# Altium schematic sheet [5]_Power_Supply.SchDoc([5]_Power_Supply)
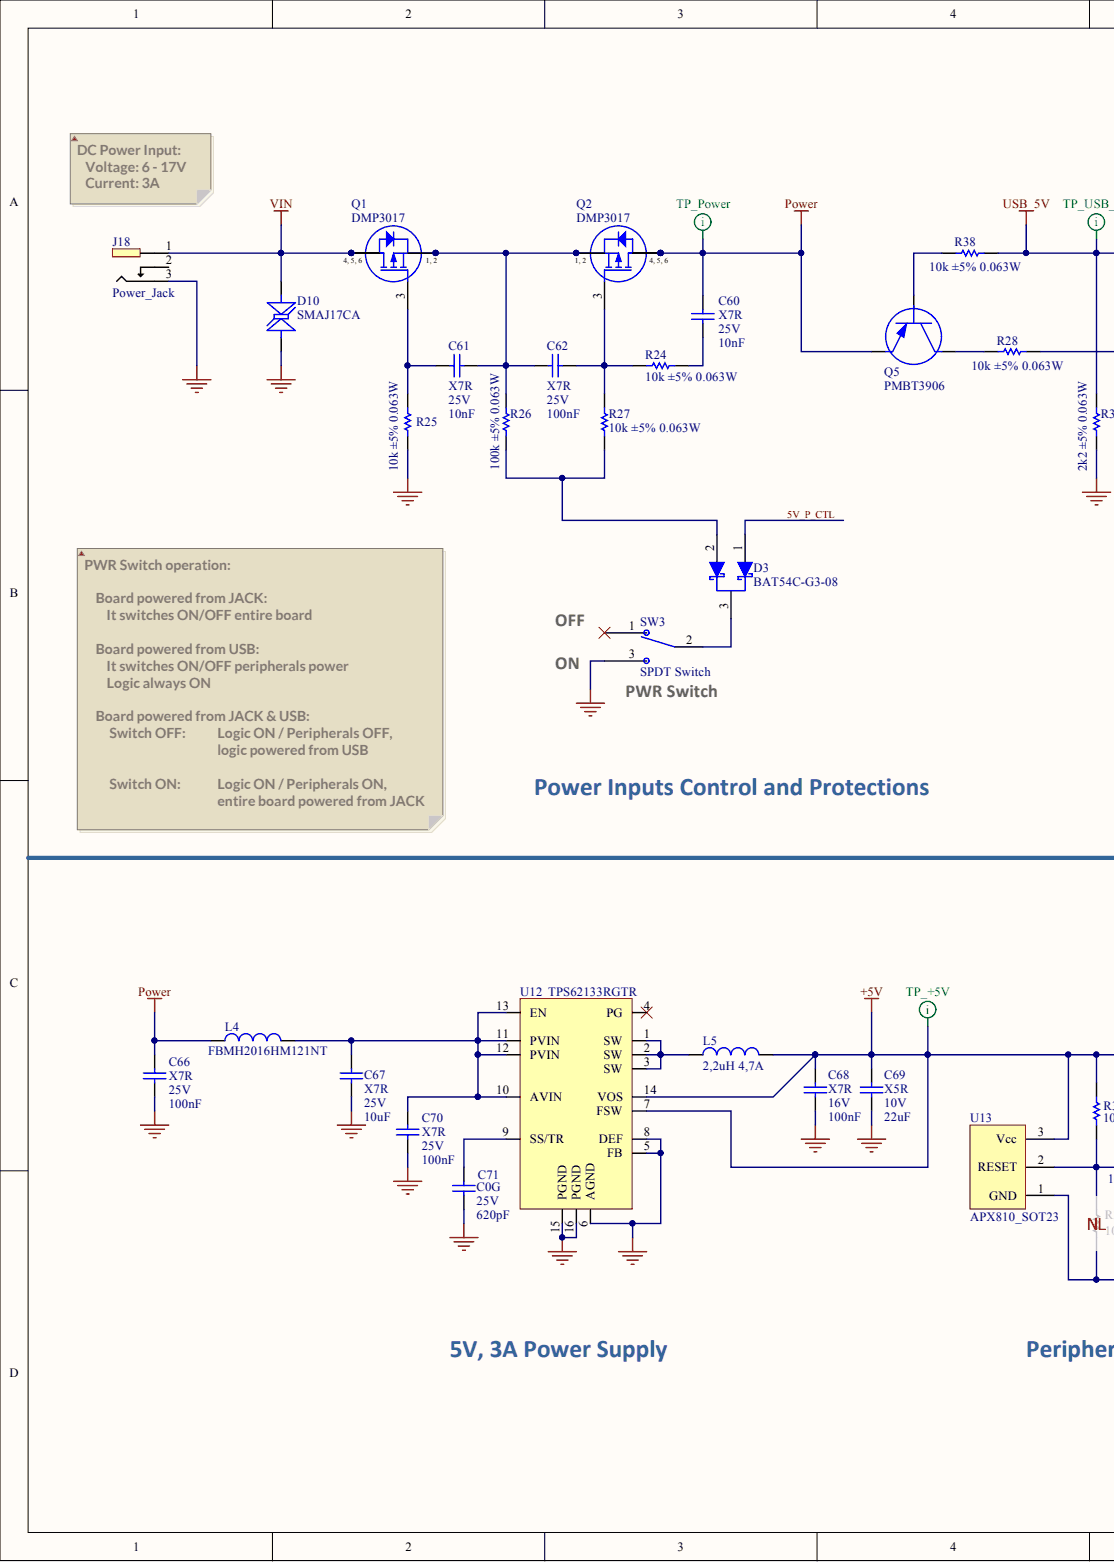
[diagram p0: [5]_Power_Supply.SchDoc, left]
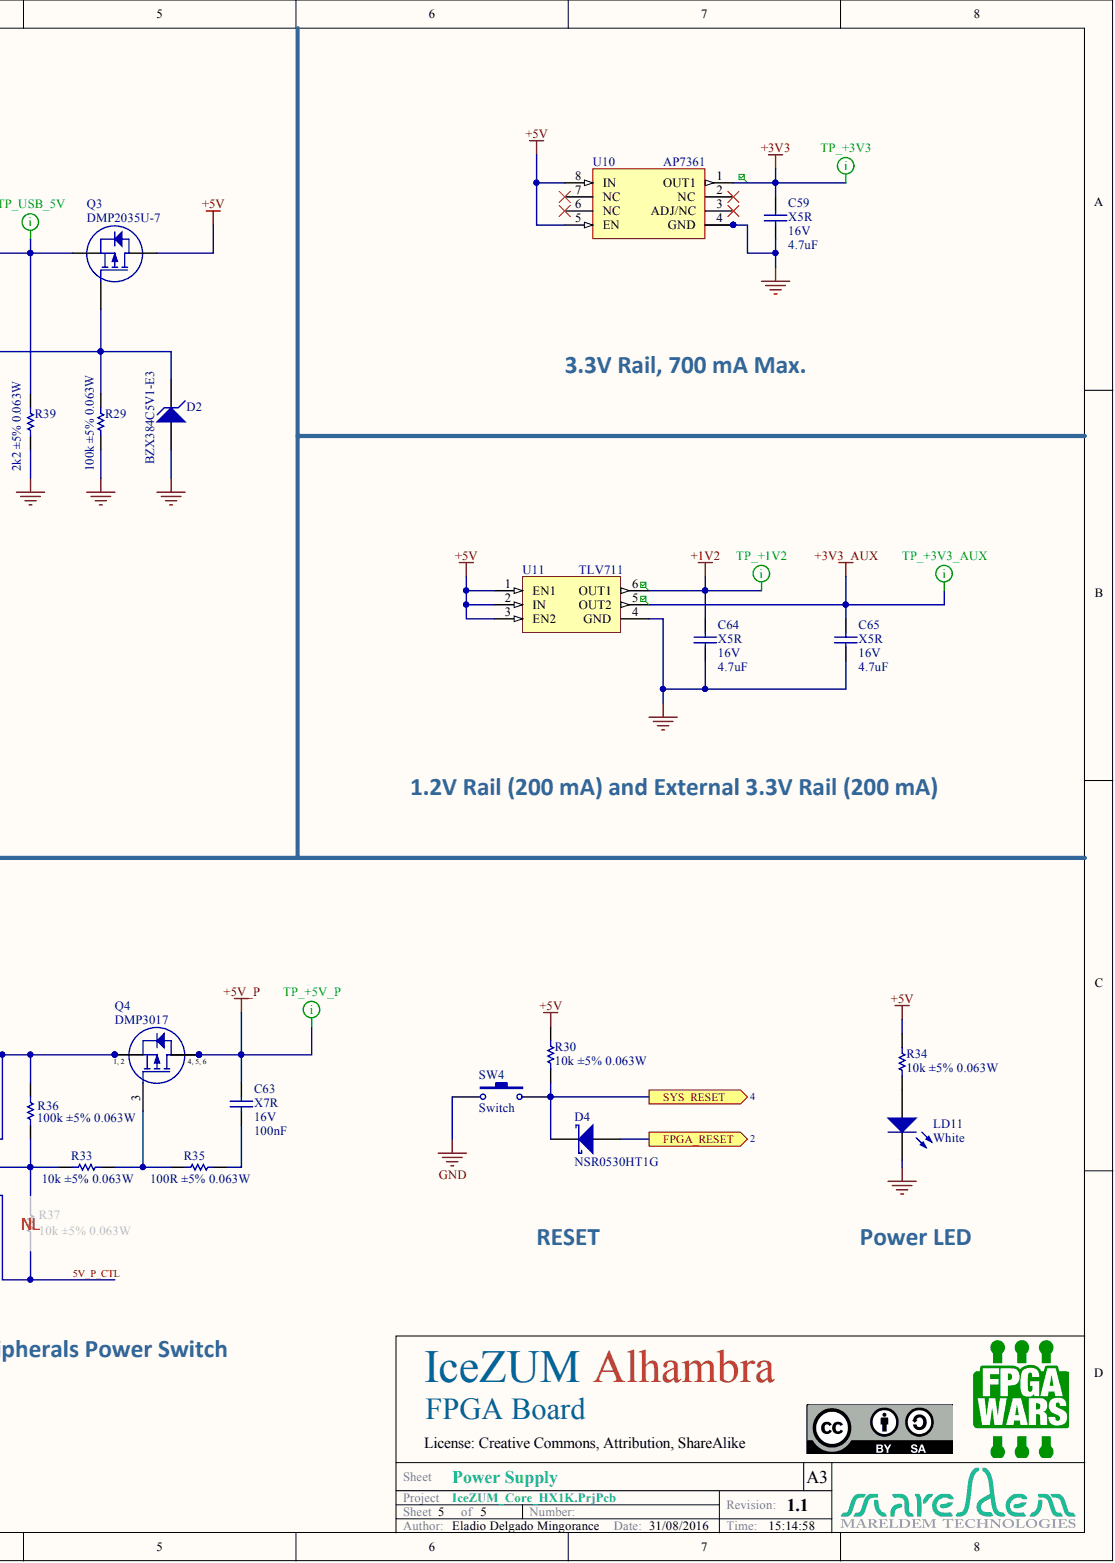
[diagram p1: [5]_Power_Supply.SchDoc, right]

## Parts
C59  Capacitance: 4.7uF  Dielectric: X5R  Voltage: 16V  [C0603]  (hidden comment: Capacitor)
C60  Capacitance: 10nF  Dielectric: X7R  Voltage: 25V  [C0603]  (hidden comment: Capacitor)
C61  Dielectric: X7R  Voltage: 25V  [C0603]  (hidden comment: Capacitor)
C62  Dielectric: X7R  Voltage: 25V  [C0603]  (hidden comment: Capacitor)
C63  Capacitance: 100nF  Dielectric: X7R  Voltage: 16V  [C0402]  (hidden comment: Capacitor)
C64  Capacitance: 4.7uF  Dielectric: X5R  Voltage: 16V  [C0603]  (hidden comment: Capacitor)
C65  Capacitance: 4.7uF  Dielectric: X5R  Voltage: 16V  [C0603]  (hidden comment: Capacitor)
C66  Capacitance: 100nF  Dielectric: X7R  Voltage: 25V  [C0603]  (hidden comment: Capacitor)
C67  Dielectric: X7R  Voltage: 25V  [C1206]  (hidden comment: Capacitor)
C68  Capacitance: 100nF  Dielectric: X7R  Voltage: 16V  [C0402]  (hidden comment: Capacitor)
C69  Capacitance: 22uF  Dielectric: X5R  Voltage: 10V  [C1206]  (hidden comment: Capacitor)
C70  Capacitance: 100nF  Dielectric: X7R  Voltage: 25V  [C0603]  (hidden comment: Capacitor)
C71  Capacitance: 620pF  Dielectric: C0G  Voltage: 25V  [C0603]  (hidden comment: Capacitor)
D2  BZX384C5V1-E3  [SOD323]
D3  BAT54C-G3-08  [SOT23-3]
D4  NSR0530HT1G  [SOD323]
D10  SMAJ17CA  [DO-214AC-SMA_Bidir]
J18  Power_Jack  [Jack 2.1]
L4  FBMH2016HM121NT  [L0806]
L5  2,2uH 4,7A  Current: 4,7A  L: 2,2uH  [L4040]
LD11  White  [LED0603]
Q1  DMP3017  [U-DFN2523-6]
Q2  DMP3017  [U-DFN2523-6]
Q3  DMP2035U-7  [SOT23-3]
Q4  DMP3017  [U-DFN2523-6]
Q5  PMBT3906  [SOT23-3]
R24  value 10k ±5% 0.063W  Power: 0.063W  Resistance: 10k  Tolerance: ±5%  [R0402]  (hidden comment: Resistor)
R25  value 10k ±5% 0.063W  Power: 0.063W  Resistance: 10k  Tolerance: ±5%  [R0402]  (hidden comment: Resistor)
R26  value 100k ±5% 0.063W  Power: 0.063W  Resistance: 100k  Tolerance: ±5%  [R0402]  (hidden comment: Resistor)
R27  value 10k ±5% 0.063W  Resistance: 10k  Tolerance: ±5%  [R0402]  (hidden comment: Resistor)
R28  value 10k ±5% 0.063W  Power: 0.063W  Resistance: 10k  Tolerance: ±5%  [R0402]  (hidden comment: Resistor)
R29  value 100k ±5% 0.063W  Power: 0.063W  Resistance: 100k  Tolerance: ±5%  [R0402]  (hidden comment: Resistor)
R30  value 10k ±5% 0.063W  Resistance: 10k  Tolerance: ±5%  [R0402]  (hidden comment: Resistor)
R33  value 10k ±5% 0.063W  Power: 0.063W  Resistance: 10k  Tolerance: ±5%  [R0402]  (hidden comment: Resistor)
R34  value 10k ±5% 0.063W  Resistance: 10k  Tolerance: ±5%  [R0402]  (hidden comment: Resistor)
R35  value 100R ±5% 0.063W  Power: 0.063W  Resistance: 100R  Tolerance: ±5%  [R0402]  (hidden comment: Resistor)
R36  value 100k ±5% 0.063W  Resistance: 100k  Tolerance: ±5%  [R0402]  (hidden comment: Resistor)
R37  value 10k ±5% 0.063W  Resistance: 10k  Tolerance: ±5%  [R0402]  (hidden comment: Resistor)
R38  value 10k ±5% 0.063W  Power: 0.063W  Resistance: 10k  Tolerance: ±5%  [R0402]  (hidden comment: Resistor)
R39  value 2k2 ±5% 0.063W  Power: 0.063W  Resistance: 2k2  Tolerance: ±5%  [R0402]  (hidden comment: Resistor)
SW3  SPDT Switch  [Slide SW SPDT Right Angle]
SW4  Switch  [SW_Vert_THT_7.5x6x6.5]
U10  AP7361  [U-DFN3030-8]
U11  TLV711  [WSON-6-1,5x1,5mm]
U12  TPS62133RGTR  [QFN16]
U13  APX810_SOT23  [SOT23-3]

## Ports
FPGA_RESET, SYS_RESET

## Nets
+1V2: C64.2 U11.6(OUT1)
+3V3: C59.2 U10.1(OUT1)
+3V3_AUX: C65.2 U11.5(OUT2)
+5V: C68.2 C69.2 L5.2 Q3.3 Q4.1 Q4.2 R30.2 R34.2 R36.1 U10.5(EN) U10.8(IN) U11.1(EN1) U11.2(IN) U11.3(EN2) U12.7(FSW) U12.14(VOS) U13.3
+5V_P: C63.2 Q4.4 Q4.5 Q4.6
GND: C59.1 C64.1 C65.1 C66.1 C67.1 C68.1 C69.1 C70.1 C71.1 D2.A D10.A J18.3 LD11.K R25.2 R29.1 R39.1 SW3.3 SW4.2 U10.0(GND) U10.4 U11.4(GND) U12.5(FB) U12.6(AGND) U12.8(DEF) U12.15(PGND) U12.16(PGND)
POWER: C60.2 C66.2 L4.1 Q2.4 Q2.5 Q2.6 Q5.2
USB_5V: Q3.2 R38.2 R39.2
VIN: D10.K J18.1 Q1.4 Q1.5 Q1.6
5V_P_CTL: D3.1 R37.2 U13.1
FPGA_RESET: D4.A ; port FPGA_RESET
SYS_RESET: D4.k R30.1 SW4.1 ; port SYS_RESET
NetC60_1: C60.1 R24.2
NetC61_1: C61.1 C62.2 Q1.1 Q1.2 Q2.1 Q2.2 R26.1
NetC61_2: C61.2 Q1.3 R25.1
NetC62_1: C62.1 Q2.3 R24.1 R27.1
NetC63_1: C63.1 R35.2
NetC67_2: C67.2 C70.2 L4.2 U12.10(AVIN) U12.11(PVIN) U12.12(PVIN) U12.13(EN)
NetC71_2: C71.2 U12.9(SS/TR)
NetD2_k: D2.k Q3.1 R28.2 R29.2
NetD3_2: D3.2 R26.2 R27.2
NetD3_3: D3.3 SW3.2
NetL5_1: L5.1 U12.1(SW) U12.2(SW) U12.3(SW)
NetLD11_A: LD11.A R34.1
NetQ4_3: Q4.3 R33.2 R35.1
NetQ5_1: Q5.1 R38.1
NetQ5_3: Q5.3 R28.1
NetR33_1: R33.1 R36.2 R37.1 U13.2

Not connected: J18 2; SW3 1; U10 2(NC) 3(ADJ/NC) 6(NC) 7(NC); U12 4(PG)

## Library data of the parts
C59 C64 C65 = C0603_4.7uF_16V_±10%: Capacitor SMD; Manufacturer: TDK; Database Table Name: C0603_16V$; OrderingCode: C1608X5R1C475K080AC; Temp_ Max: 85; Temp_ Min: -55; Tolerance: ±10%; Value: 4.7uF ±10% 16V; Component Kind: Standard; Library Name: Pasives_Capacitor_SMD.DbLib; Part Number: C0603_4.7uF_16V_±10%; Price (€): 0,068
C60 = C0603_10nF_25V_±10%: Capacitor SMD; Manufacturer: Kemet; Database Table Name: C0603_25V$; OrderingCode: C0603C103K3RAC; Temp_ Max: 125; Temp_ Min: -55; Tolerance: ±10%; Value: 10nF ±10% 25V; Component Kind: Standard; Library Name: Pasives_Capacitor_SMD.DbLib; Part Number: C0603_10nF_25V_±10%; Price (€): 0,035
C61 = C0603_10nF_25V_±10%: Capacitor SMD; Manufacturer: Kemet; Capacitance: 10nF; Database Table Name: C0603_25V$; OrderingCode: C0603C103K3RAC; Temp_ Max: 125; Temp_ Min: -55; Tolerance: ±10%; Value: 10nF ±10% 25V; Component Kind: Standard; Library Name: Pasives_Capacitor_SMD.DbLib; Part Number: C0603_10nF_25V_±10%; Price (€): 0,035
C62 = C0603_100nF_25V_±10%: Capacitor SMD; Manufacturer: Kemet; Capacitance: 100nF; Database Table Name: C0603_25V$; OrderingCode: C0603C104K3RAC; Temp_ Max: 125; Temp_ Min: -55; Tolerance: ±10%; Value: 100nF ±10% 25V; Component Kind: Standard; Library Name: Pasives_Capacitor_SMD.DbLib; Part Number: C0603_100nF_25V_±10%; Price (€): 0,035
C63 C68 = C0402_100nF_16V_±10%: Capacitor SMD; Manufacturer: Kemet; Database Table Name: C0402_16V$; OrderingCode: C0402C104K4RAC; Temp_ Max: 125; Temp_ Min: -55; Tolerance: ±10%; Value: 100nF ±10% 16V; Component Kind: Standard; Library Name: Pasives_Capacitor_SMD.DbLib; Part Number: C0402_100nF_16V_±10%; Price (€): 0,05
C66 C70 = C0603_100nF_25V_±10%: Manufacturer: Kemet; Database Table Name: C0603_25V$; OrderingCode: C0603C104K3RAC; Temp_ Max: 125; Temp_ Min: -55; Tolerance: ±10%; Value: 100nF ±10% 25V; Component Kind: Standard; Description: Capacitor; Library Name: Pasives_Capacitor_SMD.DbLib; Part Number: C0603_100nF_25V_±10%; Price (€): 0,035
C67 = C1206_10uF_25V_±10%: Capacitor SMD; Manufacturer: Taiyo Yuden; Capacitance: 10uF; Database Table Name: C1206_25V$; OrderingCode: TMK316B7106KL-TD; Temp_ Max: 125; Temp_ Min: -55; Tolerance: ±10%; Value: 10uF ±10% 25V; Component Kind: Standard; Library Name: Pasives_Capacitor_SMD.DbLib; Part Number: C1206_10uF_25V_±10%; Price (€): 0,066
C69 = C1206_22uF_10V_±20%: Manufacturer: Taiyo Yuden; Database Table Name: C1206_10V$; OrderingCode: LMK316ABJ226ML-T; Temp_ Max: 85; Temp_ Min: -55; Tolerance: ±20%; Value: 22uF ±20% 10V; Component Kind: Standard; Description: Capacitor; Library Name: Pasives_Capacitor_SMD.DbLib; Part Number: C1206_22uF_10V_±20%; Price (€): 0,094
C71 = C0603_620pF_25V_±5%: Manufacturer: Murata; Database Table Name: C0603_25V$; OrderingCode: GRM1885C1E621JA01D; Temp_ Max: 125; Temp_ Min: -55; Tolerance: ±5%; Value: 620pF ±5% 25V; Component Kind: Standard; Description: Capacitor; Library Name: Pasives_Capacitor_SMD.DbLib; Part Number: C0603_620pF_25V_±5%; Price (€): 0,023
D2 = BZX384C5V1: Zener Diode; Manufacturer: Vishay; Database Table Name: Zener$; Izener: 5mA; OrderingCode 2: BZX384B5V1-E3; Power: 200mW; Temp_ Max: 150; Temp_ Min: -55; Tolerance: 0,05; Value: 5V1; Component Kind: Standard; Library Name: Diodes.DbLib; Manufacturer 2: Vishay; OrderingCode: BZX384C5V1-E3; Part Number: BZX384C5V1; Price (€): 0,024; Vzener: 5V1
D3 = BAT54C: Dual Schottky Diode Common Cathode; Manufacturer: Vishay; Database Table Name: Schottky$; Ifor: 200mA; Ifsm: 600mA; Temp_ Max: 125; Temp_ Min: -55; Value: 200mA 30V; Vf: 0,8V; Vrrm: 30V; Component Kind: Standard; Library Name: Diodes.DbLib; Manufacturer 2: Fairchild; OrderingCode: BAT54C-G3-08; OrderingCode 2: BAT54C; Part Number: BAT54C; Price (€): 0,042
D4 = NSR0530HT1G: Schottky Diode; Manufacturer: On Semiconductor; Database Table Name: Schottky$; Ifor: 500mA; Temp_ Max: 150; Temp_ Min: -55; Value: 500mA 30V; Vf: 0,37V; Vrrm: 30V; Component Kind: Standard; Ifsm: 500mA; Library Name: Diodes.DbLib; OrderingCode: NSR0530HT1G; Part Number: NSR0530HT1G; Price (€): 0,031
D10 = TVS 17V Bi SMA: Protection, Bidirectional Transient Suppression Diodes; Manufacturer: Bourns; Breakdown Voltage: 18,9V; Database Table Name: TVS$; Overvoltage Current: 14,5A; Temp_ Max: 150; Temp_ Min: -55; Voltage: 17V; Component Kind: Standard; Library Name: Protection.DbLib; Manufacturer 2: Littelfuse; OrderingCode: SMAJ17CA; OrderingCode 2: SMAJ17CA; Part Number: TVS 17V Bi SMA; Price (€): 0,113
J18 = DC Power Jack: Connector, Power, DC Power Jack; Manufacturer: Kycon; Database Table Name: Jack$; Idc: 5A; OrderingCode: KLDHCX0202A; OrderingCode 2: RAPC722X; Component Kind: Standard; Library Name: Connectors_Power.DbLib; Manufacturer 2: Switchcraft; Part Number: DC Power Jack; Price (€): 0,2673; Supplier: Avnet
L4 = L0806_120R_0,015R_4,5A: Ferrite Bead; Manufacturer: Taiyo Yuden; Current: 4,5A; Database Table Name: Ferritas$; Resistance_100MHz: 120R; Resistance_DC: 0,015R; Temp_ Max: 125; Temp_ Min: -40; Value: 120R 0,015R 4,5A; Component Kind: Standard; Library Name: Pasives_Inductor_SMD.DbLib; OrderingCode: FBMH2016HM121NT; Part Number: L0806_120R_0,015R_4,5A; Price (€): 0,05
L5 = 2,2uH_4,7A: Inductor SMD; Manufacturer: Würth Elektronik; Current Sat#: 6,2A; Database Table Name: Power Inductor$; OrderingCode: 74438356022; OrderingCode 2: XAL4020-222MEB; Rdc: 0,029R; Temp_ Max: 125; Temp_ Min: -40; Component Kind: Standard; Library Name: Pasives_Inductor_SMD.DbLib; Manufacturer 2: Coilcraft; Part Number: 2,2uH_4,7A; Price (€): 1,22; Supplier: Würth; Value: 2,2uH 4,7A
LD11 = LW Q38G-Q2R3: LED; Manufacturer: OSRAM Opto; Database Table Name: LED_SMD$; OrderingCode: LW Q38G-Q2R2-3K5L-1; Temp_ Max: 85; Temp_ Min: -40; Component Kind: Standard; Library Name: Optoelectronics.SVNDbLib; Part Number: LW Q38G-Q2R3; Price (€): 0,1; Value: White
Q1 Q2 Q4 = DMP3017: P-Channel MOSFET, 6 pin; Manufacturer: Diodes Incorporated; Database Table Name: MOSFET$; Id: 10,4A; OrderingCode: DMP3017SFK-7; Temp_ Max: 150; Temp_ Min: -55; Vds: 30V; Vgss: 25V; Component Kind: Standard; Library Name: Transistors.DbLib; Part Number: DMP3017; Price (€): 0,256; Supplier: Silica
Q3 = DMP2035U: PFET; Manufacturer: Diodes Incorporated; Database Table Name: MOSFET$; Id: 3,6A; Temp_ Max: 150; Temp_ Min: -55; Vds: 20V; Vgss: 8V; Component Kind: Standard; Library Name: Semiconductors_Transistors.SVNDbLib; OrderingCode: DMP2035U-7; Part Number: DMP2035U; Price (€): 0,08; Supplier: Silica
Q5 = PMBT3906: PNP; Manufacturer: NXP; Database Table Name: BJT$; Ic: 200mA; OrderingCode: PMBT3906,215; Temp_ Max: 150; Temp_ Min: -65; Vcbo: -40V; Vebo: -6V; Component Kind: Standard; Library Name: Semiconductors_Transistors.SVNDbLib; Part Number: PMBT3906; Price (€): 0,019; Vceo: -40V
R24 R25 R28 R33 R38 = R0402_10k_0.063W_±5%: Resistor, SMD; Manufacturer: Vishay; Database Table Name: R0402_5%$; OrderingCode: CRCW040210K0JN; Temp_ Max: 155; Temp_ Min: -55; Component Kind: Standard; Library Name: Pasives_Resistor_SMD.DbLib; PackageDescription: SMD; Part Number: R0402_10k_0.063W_±5%; Price (€): 0,003
R26 R29 = R0402_100k_0.063W_±5%: Resistor, SMD; Manufacturer: Vishay; Database Table Name: R0402_5%$; OrderingCode: CRCW0402100KJN; Temp_ Max: 155; Temp_ Min: -55; Component Kind: Standard; Library Name: Pasives_Resistor_SMD.DbLib; PackageDescription: SMD; Part Number: R0402_100k_0.063W_±5%; Price (€): 0,003
R27 R30 R34 R37 = R0402_10k_0.063W_±5%: Resistor, SMD; Manufacturer: Vishay; Database Table Name: R0402_5%$; OrderingCode: CRCW040210K0JN; Power: 0.063W; Temp_ Max: 155; Temp_ Min: -55; Component Kind: Standard; Library Name: Pasives_Resistor_SMD.DbLib; PackageDescription: SMD; Part Number: R0402_10k_0.063W_±5%; Price (€): 0,003
R35 = R0402_100R_0.063W_±5%: Resistor, SMD; Manufacturer: Vishay; Database Table Name: R0402_5%$; OrderingCode: CRCW0402100RJN; Temp_ Max: 155; Temp_ Min: -55; Component Kind: Standard; Library Name: Pasives_Resistor_SMD.DbLib; PackageDescription: SMD; Part Number: R0402_100R_0.063W_±5%; Price (€): 0,003
R36 = R0402_100k_0.063W_±5%: Resistor, SMD; Manufacturer: Vishay; Database Table Name: R0402_5%$; OrderingCode: CRCW0402100KJN; Power: 0.063W; Temp_ Max: 155; Temp_ Min: -55; Value: 100k ±5% 0.063W; Component Kind: Standard; Library Name: Pasives_Resistor_SMD.DbLib; PackageDescription: SMD; Part Number: R0402_100k_0.063W_±5%; Price (€): 0,003
R39 = R0402_2k2_0.063W_±5%: Resistor, SMD; Manufacturer: Vishay; Database Table Name: R0402_5%$; OrderingCode: CRCW04022K20JN; Temp_ Max: 155; Temp_ Min: -55; Component Kind: Standard; Library Name: Pasives_Resistor_SMD.DbLib; PackageDescription: SMD; Part Number: R0402_2k2_0.063W_±5%; Price (€): 0,003
SW3 = Switch SPDT: Manufacturer: Würth Elektronik; Database Table Name: Switch$; OrderingCode: 450 302 014 072; OrderingCode 2: MMP1010D-RA; Temp_ Max: 85; Temp_ Min: -40; Component Kind: Standard; Description: SPDT Switch; Library Name: Electromechanical.SVNDbLib; Manufacturer 2: Knitter-Switch; Part Number: Switch SPDT; Price (€): 0,4144; Supplier: Würth
SW4 = SW Vertical THT: Micro miniature pushbutton surface Switch; Manufacturer: C&K Components; Database Table Name: Switch$; OrderingCode: PTS645VL39-2 LFS; Temp_ Max: 60; Temp_ Min: -20; Component Kind: Standard; Library Name: Electromechanical.SVNDbLib; Part Number: SW Vertical THT; Price (€): 0,104; Supplier: Abacus
U10 = AP7361: LDO Regulator, 8 pin, adjustable and fixed mode with enable; Manufacturer: Diodes Inc.; Current OUT: 1A; Database Table Name: LDO$; OrderingCode: AP7361-33FGE-7; Temp_ Max: 85; Temp_ Min: -40; Voltage IN: 2,2÷6 VDC; Voltage OUT: 3.3VDC; Component Kind: Standard; Library Name: Power_Regulator.DbLib; Part Number: AP7361; Price (€): 0,187; Supplier: Silica
U11 = TLV711: LDO Regulator, Dual, 6 pin; Manufacturer: Texas Instruments; Current OUT: 200 & 200 mA; Database Table Name: LDO$; OrderingCode: TLV7111233DSER; Temp_ Max: 125; Temp_ Min: -40; Voltage IN: 2÷5,5 VDC; Voltage OUT: 1,2 & 3,3 V; Component Kind: Standard; Library Name: Power_Regulator.DbLib; Part Number: TLV711; Price (€): 0,224; Supplier: Silica
U12 = TPS62133: 3A High Efficient Synchronous Step Down Converter with DCS™ Control; Manufacturer: Texas Instruments; Database Table Name: DC_DC_Switching_Reg$; Temp_ Max: 85; Temp_ Min: -40; Voltage IN: 3÷17 VDC; Voltage OUT: 0,9÷6 VDC; Component Kind: Standard; Current OUT: 3A; Library Name: Power_Regulator.DbLib; OrderingCode: TPS62133RGTR; Part Number: TPS62133; Price (€): 1,5; Supplier: Silica
U13 = APX810: Voltage supervisor, Reset active high, 200ms; Manufacturer: Diodes Incorporated; Database Table Name: RESET$; OrderingCode: APX810-29SAG-7; Temp_ Max: 105; Temp_ Min: -40; Value: 2,93V; Component Kind: Standard; Library Name: Power_Supervisor.DbLib; Part Number: APX810; Price (€): 0,1; Supplier: Silica
